# Altium schematic sheet MCU.SchDoc(MCU)
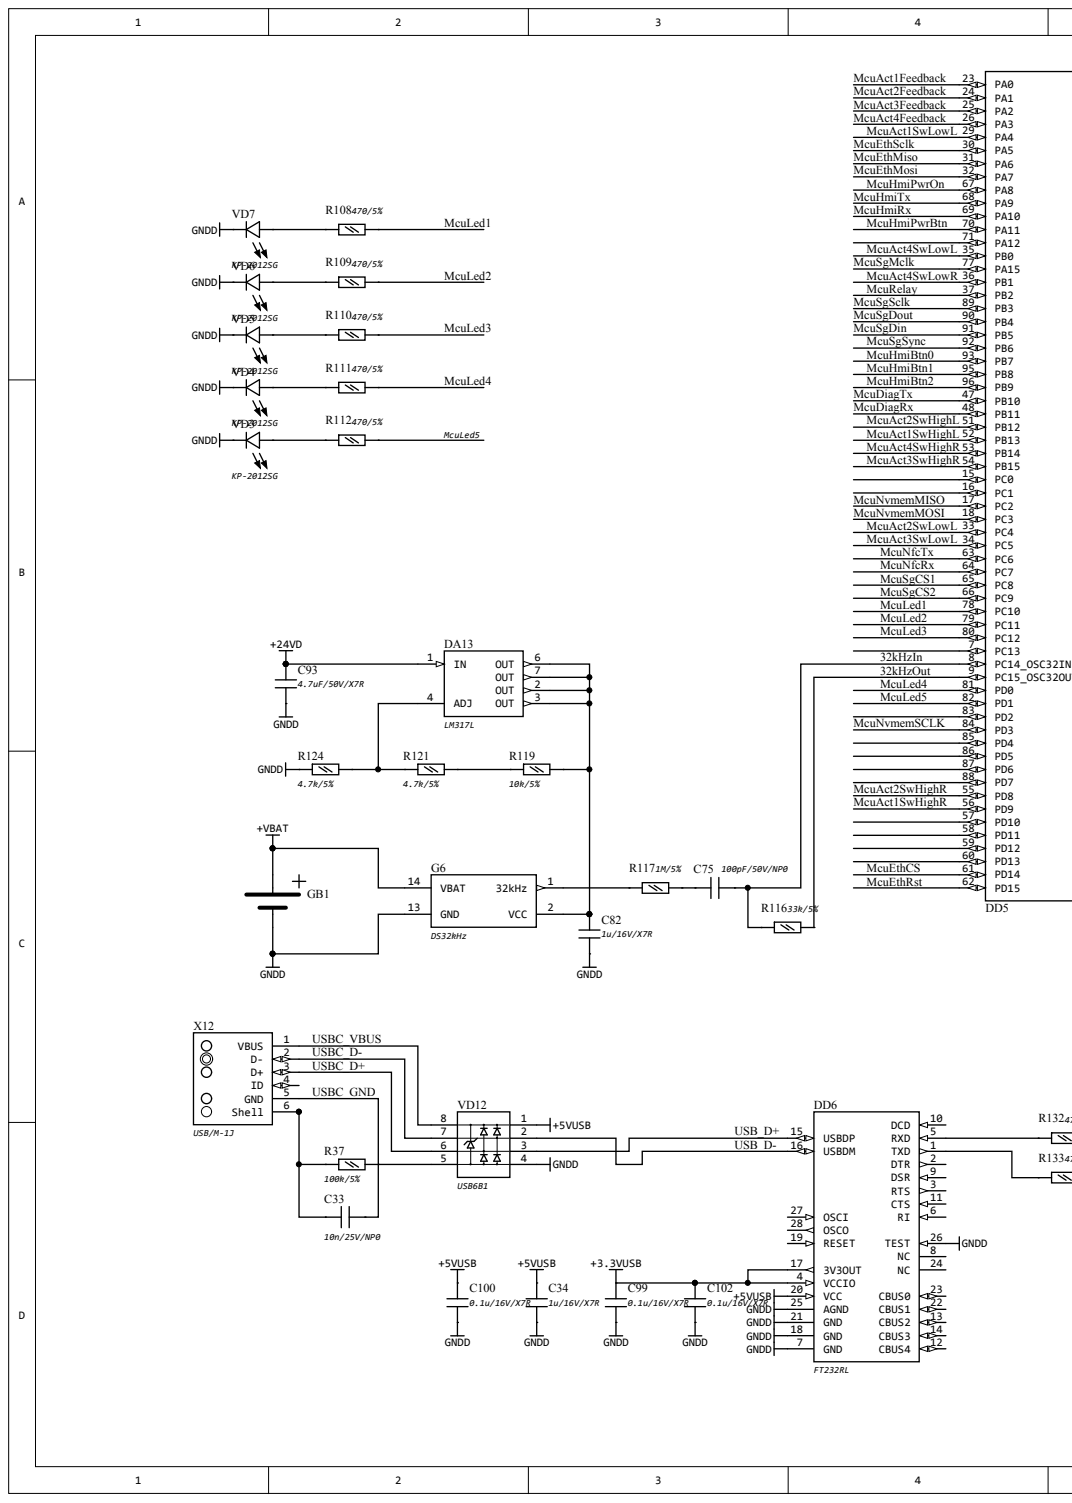
[diagram p0: MCU.SchDoc, left]
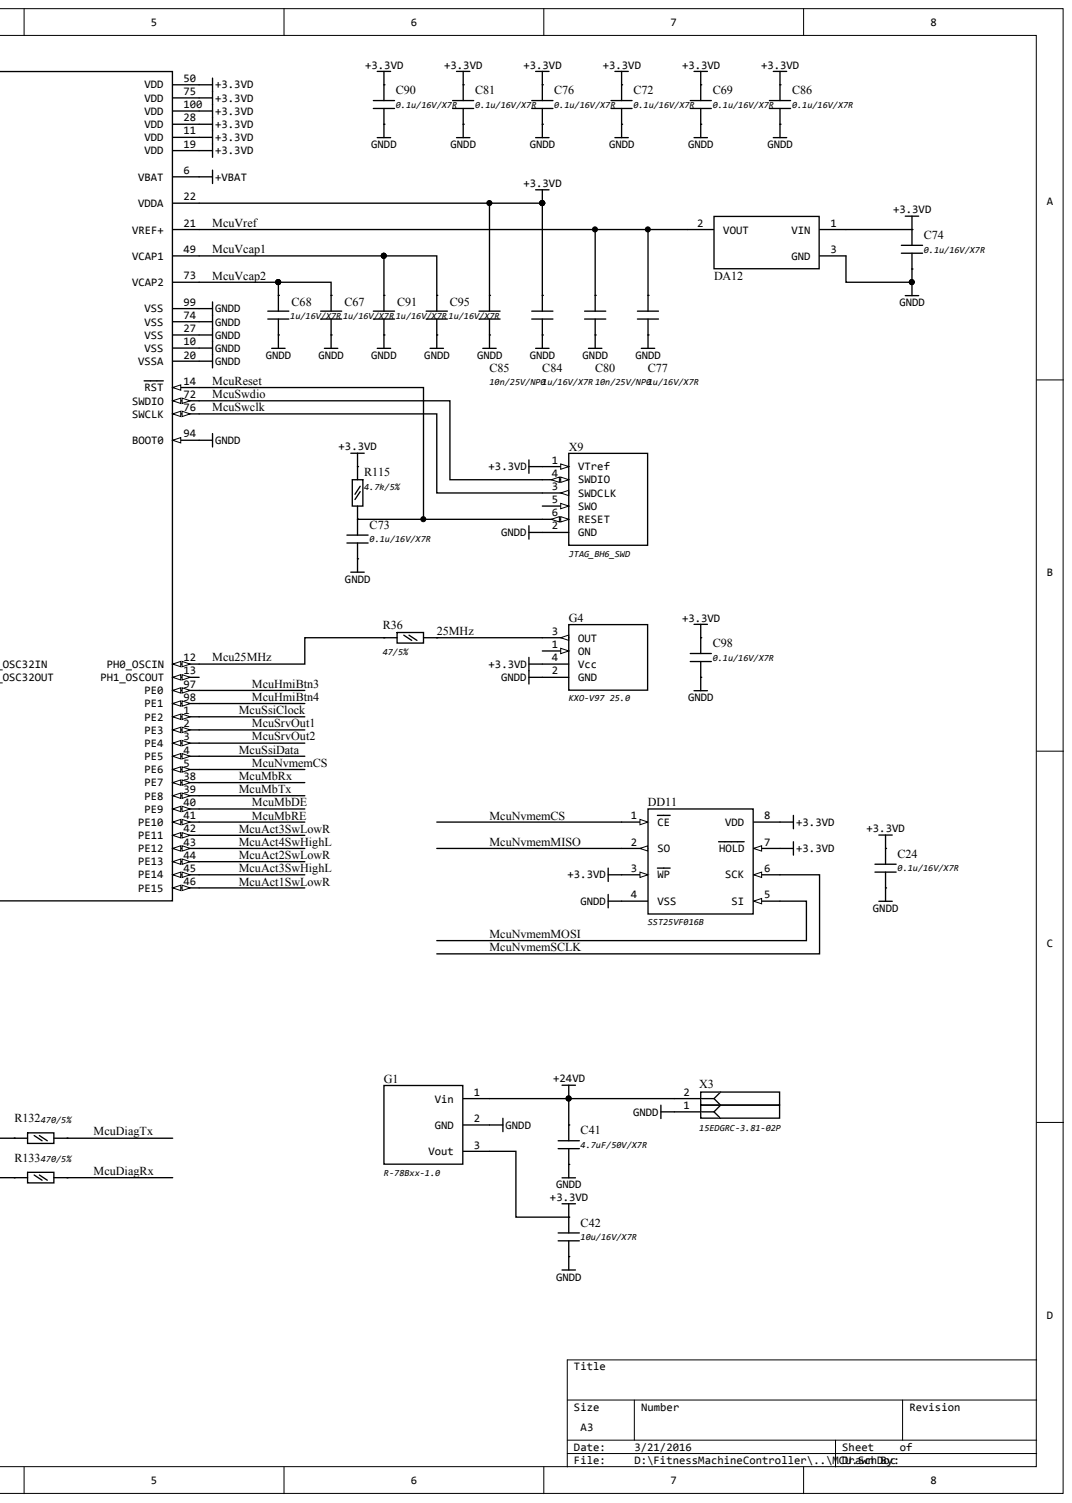
[diagram p1: MCU.SchDoc, right]

## Parts
C24  0.1u/16V/X7R
C33  10n/25V/NP0
C34  1u/16V/X7R
C41  4.7uF/50V/X7R
C42  10u/16V/X7R
C67  1u/16V/X7R
C68  1u/16V/X7R
C69  0.1u/16V/X7R
C72  0.1u/16V/X7R
C73  0.1u/16V/X7R
C74  0.1u/16V/X7R
C75  100pF/50V/NP0
C76  0.1u/16V/X7R
C77  1u/16V/X7R
C80  10n/25V/NP0
C81  0.1u/16V/X7R
C82  1u/16V/X7R
C84  1u/16V/X7R
C85  10n/25V/NP0
C86  0.1u/16V/X7R
C90  0.1u/16V/X7R
C91  1u/16V/X7R
C93  4.7uF/50V/X7R
C95  1u/16V/X7R
C98  0.1u/16V/X7R
C99  0.1u/16V/X7R
C100  0.1u/16V/X7R
C102  0.1u/16V/X7R
DA12  REF30xxAIDBZ  (value not drawn)
DA13  LM317L
DD5  STM32F427VG  (value not drawn)
DD6  FT232RL
DD11  SST25VF016B
G1  R-78Bxx-1.0
G4  KXO-V97 25.0
G6  DS32kHz
GB1  CH224-2032  (value not drawn)
R36  47/5%
R37  100k/5%
R108  470/5%
R109  470/5%
R110  470/5%
R111  470/5%
R112  470/5%
R115  4.7k/5%
R116  33k/5%
R117  1M/5%
R119  10k/5%
R121  4.7k/5%
R124  4.7k/5%
R132  470/5%
R133  470/5%
VD3  KP-2012SG
VD4  KP-2012SG
VD5  KP-2012SG
VD6  KP-2012SG
VD7  KP-2012SG
VD12  USB6B1
X3  15EDGRC-3.81-02P
X9  JTAG_BH6_SWD
X12  USB/M-1J

## Nets
+3.3VD: C24.2 C42.2 C69.2 C72.2 C74.2 C76.2 C81.2 C84.2 C85.2 C86.2 C90.2 C98.2 DA12.1 DD5.11(VDD) DD5.19(VDD) DD5.22(VDDA) DD5.28(VDD) DD5.50(VDD) DD5.75(VDD) DD5.100(VDD) DD11.3(WP) DD11.7(HOLD) DD11.8(VDD) G1.3 G4.4(Vcc) R115.2 X9.1(VTref)
+3.3VUSB: C99.2 C102.2 DD6.4(VCCIO) DD6.17(3V3OUT)
+5VUSB: C34.2 C100.2 DD6.20(VCC) VD12.1
+24VD: C41.2 C93.2 DA13.1(IN) G1.1 X3.2
+VBAT: DD5.6(VBAT) G6.14(VBAT) GB1.1
GNDD: C24.1 C34.1 C41.1 C42.1 C67.1 C68.1 C69.1 C72.1 C73.1 C74.1 C76.1 C77.1 C80.1 C81.1 C82.1 C84.1 C85.1 C86.1 C90.1 C91.1 C93.1 C95.1 C98.1 C99.1 C100.1 C102.1 DA12.3 DD5.10(VSS) DD5.20(VSSA) DD5.27(VSS) DD5.74(VSS) DD5.94(BOOT0) DD5.99(VSS) DD6.7(GND) DD6.18(GND) DD6.21(GND) DD6.25(AGND) DD6.26(TEST) DD11.4(VSS) G1.2 G4.2(GND) G6.13(GND) GB1.2 R124.2 VD3.2 VD4.2 VD5.2 VD6.2 VD7.2 VD12.4 X3.1 X9.2(GND)
25MHZ: G4.3(OUT) R36.1
32KHZIN: C75.2 DD5.8(PC14_OSC32IN) R116.2
32KHZOUT: DD5.9(PC15_OSC32OUT) R116.1
MCU25MHZ: DD5.12(PH0_OSCIN) R36.2
MCUACT1FEEDBACK: DD5.23(PA0)
MCUACT1SWHIGHL: DD5.52(PB13)
MCUACT1SWHIGHR: DD5.56(PD9)
MCUACT1SWLOWL: DD5.29(PA4)
MCUACT1SWLOWR: DD5.46(PE15)
MCUACT2FEEDBACK: DD5.24(PA1)
MCUACT2SWHIGHL: DD5.51(PB12)
MCUACT2SWHIGHR: DD5.55(PD8)
MCUACT2SWLOWL: DD5.33(PC4)
MCUACT2SWLOWR: DD5.44(PE13)
MCUACT3FEEDBACK: DD5.25(PA2)
MCUACT3SWHIGHL: DD5.45(PE14)
MCUACT3SWHIGHR: DD5.54(PB15)
MCUACT3SWLOWL: DD5.34(PC5)
MCUACT3SWLOWR: DD5.42(PE11)
MCUACT4FEEDBACK: DD5.26(PA3)
MCUACT4SWHIGHL: DD5.43(PE12)
MCUACT4SWHIGHR: DD5.53(PB14)
MCUACT4SWLOWL: DD5.35(PB0)
MCUACT4SWLOWR: DD5.36(PB1)
MCUDIAGRX: DD5.48(PB11) R133.1
MCUDIAGTX: DD5.47(PB10) R132.1
MCUETHCS: DD5.61(PD14)
MCUETHMISO: DD5.31(PA6)
MCUETHMOSI: DD5.32(PA7)
MCUETHRST: DD5.62(PD15)
MCUETHSCLK: DD5.30(PA5)
MCUHMIBTN0: DD5.93(PB7)
MCUHMIBTN1: DD5.95(PB8)
MCUHMIBTN2: DD5.96(PB9)
MCUHMIBTN3: DD5.97(PE0)
MCUHMIBTN4: DD5.98(PE1)
MCUHMIPWRBTN: DD5.70(PA11)
MCUHMIPWRON: DD5.67(PA8)
MCUHMIRX: DD5.69(PA10)
MCUHMITX: DD5.68(PA9)
MCULED1: DD5.78(PC10) R108.1
MCULED2: DD5.79(PC11) R109.1
MCULED3: DD5.80(PC12) R110.1
MCULED4: DD5.81(PD0) R111.1
MCULED5: DD5.82(PD1) R112.1
MCUMBDE: DD5.40(PE9)
MCUMBRE: DD5.41(PE10)
MCUMBRX: DD5.38(PE7)
MCUMBTX: DD5.39(PE8)
MCUNFCRX: DD5.64(PC7)
MCUNFCTX: DD5.63(PC6)
MCUNVMEMCS: DD5.5(PE6) DD11.1(CE)
MCUNVMEMMISO: DD5.17(PC2) DD11.2(SO)
MCUNVMEMMOSI: DD5.18(PC3) DD11.5(SI)
MCUNVMEMSCLK: DD5.84(PD3) DD11.6(SCK)
MCURELAY: DD5.37(PB2)
MCURESET: C73.2 DD5.14(RST) R115.1 X9.6(RESET)
MCUSGCS1: DD5.65(PC8)
MCUSGCS2: DD5.66(PC9)
MCUSGDIN: DD5.91(PB5)
MCUSGDOUT: DD5.90(PB4)
MCUSGMCLK: DD5.77(PA15)
MCUSGSCLK: DD5.89(PB3)
MCUSGSYNC: DD5.92(PB6)
MCUSRVOUT1: DD5.2(PE3)
MCUSRVOUT2: DD5.3(PE4)
MCUSSICLOCK: DD5.1(PE2)
MCUSSIDATA: DD5.4(PE5)
MCUSWCLK: DD5.76(SWCLK) X9.3(SWDCLK)
MCUSWDIO: DD5.72(SWDIO) X9.4(SWDIO)
MCUVCAP1: C91.2 C95.2 DD5.49(VCAP1)
MCUVCAP2: C67.2 C68.2 DD5.73(VCAP2)
MCUVREF: C77.2 C80.2 DA12.2 DD5.21(VREF+)
USB_D+: DD6.15(USBDP) VD12.3
USB_D-: DD6.16(USBDM) VD12.2
USBC_D+: VD12.6 X12.3(D+)
USBC_D-: VD12.7 X12.2(D-)
NetC33_1: C33.1 R37.2 X12.6(Shell)
NetC33_2: C33.2 R37.1 VD12.5 X12.5(GND)
NetC75_1: C75.1 R117.2
NetC82_2: C82.2 DA13.2(OUT) DA13.3(OUT) DA13.6(OUT) DA13.7(OUT) G6.2(VCC) R119.1
NetDA13_4: DA13.4(ADJ) R121.2 R124.1
NetDD6_1: DD6.1(TXD) R133.2
NetDD6_5: DD6.5(RXD) R132.2
NetDD6_6: DD6.6(RI)
NetDD6_8: DD6.8(NC)
NetG6_1: G6.1(32kHz) R117.1
NetR108_2: R108.2 VD7.1
NetR109_2: R109.2 VD6.1
NetR110_2: R110.2 VD5.1
NetR111_2: R111.2 VD4.1
NetR112_2: R112.2 VD3.1
NetR119_2: R119.2 R121.1
NetVD12_8: VD12.8 X12.1(VBUS)
USBC_GND:
USBC_VBUS:

Not connected: DD5 7(PC13) 13(PH1_OSCOUT) 15(PC0) 16(PC1) 57(PD10) 58(PD11) 59(PD12) 60(PD13) 71(PA12) 83(PD2) 85(PD4) 86(PD5) 87(PD6) 88(PD7); DD6 2(DTR) 3(RTS) 9(DSR) 10(DCD) 11(CTS) 12(CBUS4) 13(CBUS2) 14(CBUS3) 19(RESET) 22(CBUS1) 23(CBUS0) 24(NC) 27(OSCI) 28(OSCO); G4 1(ON); X9 5(SWO); X12 4(ID)

## Library data of the parts
C24 C33 C69 C72-C76 C80 C81 C85 C86 C90 C98-C100 C102 = (no symbol name): ComponentDescriptionRUS: Конденсатор SMD 0603; Analog: нет; NumberSolderPointsSMD: 0; NumberSolderPointsTHT: 0
C34 C67 C68 C77 C82 C84 C91 C95 = (no symbol name): ComponentDescriptionRUS: Конденсатор SMD 0805; Analog: нет; NumberSolderPointsSMD: 0; NumberSolderPointsTHT: 0
C41 C93 = (no symbol name): ComponentDescriptionRUS: Конденсатор SMD 1210; Analog: нет; NumberSolderPointsSMD: 0; NumberSolderPointsTHT: 0
C42 = (no symbol name): ComponentDescriptionRUS: Конденсатор SMD 1206; Analog: нет; NumberSolderPointsSMD: 0; NumberSolderPointsTHT: 0
DA12 = (no symbol name): ComponentDescriptionRUS: Микросхема SOT23; Analog: нет; NumberSolderPointsSMD: 0; NumberSolderPointsTHT: 0
DA13 = (no symbol name): NumberSolderPointsSMD: 0; Аналог: нет; NumberSolderPointsTHT: 0
DD5 = (no symbol name): ComponentDescriptionRUS: Микросхема LQFP100; Analog: нет; NumberSolderPointsSMD: 0; NumberSolderPointsTHT: 0
DD6 VD12 X9 X12 = (no symbol name): Analog: нет; NumberSolderPointsSMD: 0; NumberSolderPointsTHT: 0
G4 = (no symbol name): ComponentDescriptionRUS: Кварц. генератор SMD; Analog: нет; NumberSolderPointsSMD: 0; NumberSolderPointsTHT: 0
G6 = (no symbol name): ComponentDescriptionRUS: Микросхема SO16; Analog: нет; NumberSolderPointsSMD: 0; NumberSolderPointsTHT: 0
GB1 = (no symbol name): ComponentDescriptionRUS: Литиевая батарейка; Analog: нет; NumberSolderPointsSMD: 0; NumberSolderPointsTHT: 0
R36 R37 R108-R112 R115-R117 R119 R121 R124 R132 R133 = (no symbol name): ComponentDescriptionRUS: Резистор SMD 0603; Analog: нет; NumberSolderPointsSMD: 0; NumberSolderPointsTHT: 0
VD3-VD7 = (no symbol name): Описание: Светодиод SMD 0805; NumberSolderPointsSMD: 0; Аналог: нет; NumberSolderPointsTHT: 0
X3 = (no symbol name): Аналог: ECH381R-02P; NumberSolderPointsSMD: 0; Комплект: EC381V-02P, 15EDGK-3.81-02; NumberSolderPointsTHT: 0
